# Altium schematic sheet 3 Bias Supply.SchDoc
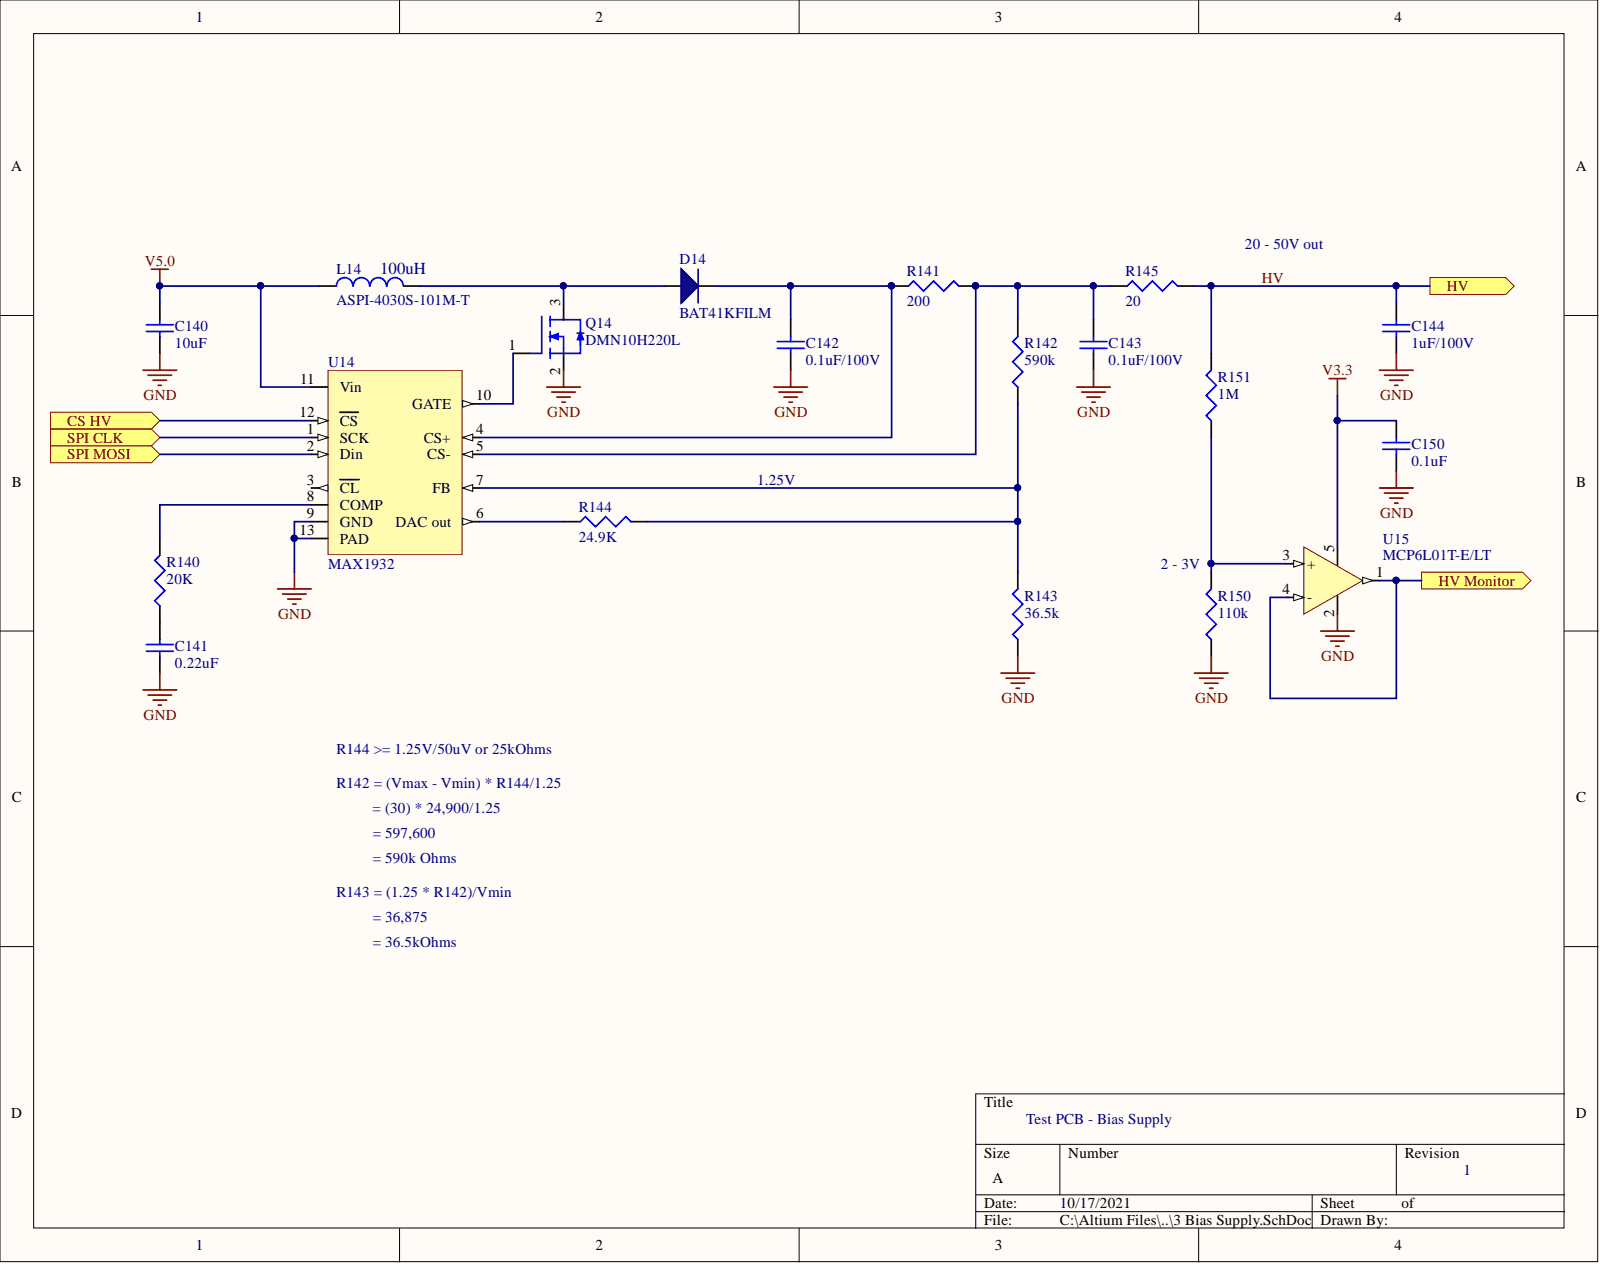
[diagram p0: 3 Bias Supply.SchDoc]

## Parts
C140  10uF  [C0805]
C141  0.22uF  [C0603]
C142  0.1uF/100V  [C0603]
C143  0.1uF/100V  [C0603]
C144  1uF/100V  [C0805]
C150  0.1uF  [C0402]
D14  BAT41KFILM  [SOD523]
L14  ASPI-4030S-101M-T  Inductance: 100uH  [L_4018]
Q14  DMN10H220L  [SOT23-3]
R140  20K  [R0603]
R141  200  [R0603]
R142  590k  [R0603]
R143  36.5k  [R0603]
R144  24.9K  [R0603]
R145  20  [R0603]
R150  110k  [R0603]
R151  1M  [R0603]
U14  MAX1932  [QFN127P600-12N]
U15  MCP6L01T-E/LT  [SC70-5]

## Ports
CS HV, HV, HV Monitor, SPI CLK, SPI MOSI

## Nets
GND: C140.1 C141.1 C142.1 C143.1 C144.1 C150.1 Q14.2 R143.1 R150.1 U14.9(GND) U14.13(PAD) U15.2
V3.3: C150.2 U15.5
V5.0: C140.2 L14.1 U14.11(Vin)
CS HV: U14.12(CS) ; port CS HV
HV: C144.2 R145.2 R151.2 ; port HV
HV Monitor: U15.1 U15.4(-) ; port HV Monitor
SPI CLK: U14.1(SCK) ; port SPI CLK
SPI MOSI: U14.2(Din) ; port SPI MOSI
NetC141_2: C141.2 R140.1
NetC142_2: C142.2 D14.2 R141.1 U14.4(CS+)
NetC143_2: C143.2 R141.2 R142.2 R145.1 U14.5(CS-)
NetD14_1: D14.1 L14.2 Q14.3
NetQ14_1: Q14.1 U14.10(GATE)
NetR140_2: R140.2 U14.8(COMP)
NetR142_1: R142.1 R143.2 R144.2 U14.7(FB)
NetR144_1: R144.1 U14.6(DAC_out)
NetR150_2: R150.2 R151.1 U15.3(+)

Not connected: U14 3(CL)

## Library data of the parts
C140-C144 C150 = Cap SM: Capacitor, SM; Component Kind: Standard; LatestRevisionDate: 29-May-2009; LatestRevisionNote: IPC-7351 Footprint Added.; PackageDescription: Chip Capacitor; PackageReference: C1206; Published: 8-Jun-2000; Library Name: Graylark.IntLib; Publisher: Altium Limited
  C140: Signal Integrity: Cap Semi; Simulation: CAP; Value: 10uF
  C141: Signal Integrity: Cap Semi; Simulation: CAP; Value: 0.22uF
  C142 C143: Signal Integrity: Cap Semi; Simulation: CAP; Value: 0.1uF/100V
  C144: Signal Integrity: Cap Semi; Simulation: CAP; Value: 1uF/100V
  C150: Value: 0.1uF
D14 = BAT41KFILM: Schottky diode; Component Kind: Standard; Part Number: BAT41KFILM; Library Name: Graylark.IntLib
L14 = Inductor: 47uH inductor; Component Kind: Standard; Part Number: ASPI-4030S-101M-T; Library Name: Graylark.IntLib
Q14 = N FET: Part Number: DMN10H220L-7; Component Kind: Standard; Description: N FET; Library Name: Graylark.IntLib
R140-R145 R150 R151 = Res SM: Resistor; Component Kind: Standard; LatestRevisionDate: 29-May-2009; LatestRevisionNote: IPC-7351 Footprint Added.; PackageDescription: Chip Resistor; PackageReference: J1-0603; Published: 8-Jun-2000; Signal Integrity: Res; Simulation: RESISTOR; Library Name: Graylark.IntLib; Publisher: Altium Limited
  R140: Value: 20K
  R141: Value: 200
  R142: Value: 590k
  R143: Value: 36.5k
  R144: Value: 24.9K
  R145: Value: 20
  R150: Value: 110k
  R151: Value: 1M
U14 = MAX1932: APD bias supply; Component Kind: Standard; Part Number: MAX1932; Library Name: Graylark.IntLib
U15 = MCP6L01: Op amp RRIO; Component Kind: Standard; Part Number: MCP6L01T-E/LT; Library Name: Graylark.IntLib
